# Altium schematic sheet ESP32.SchDoc(ESP32)
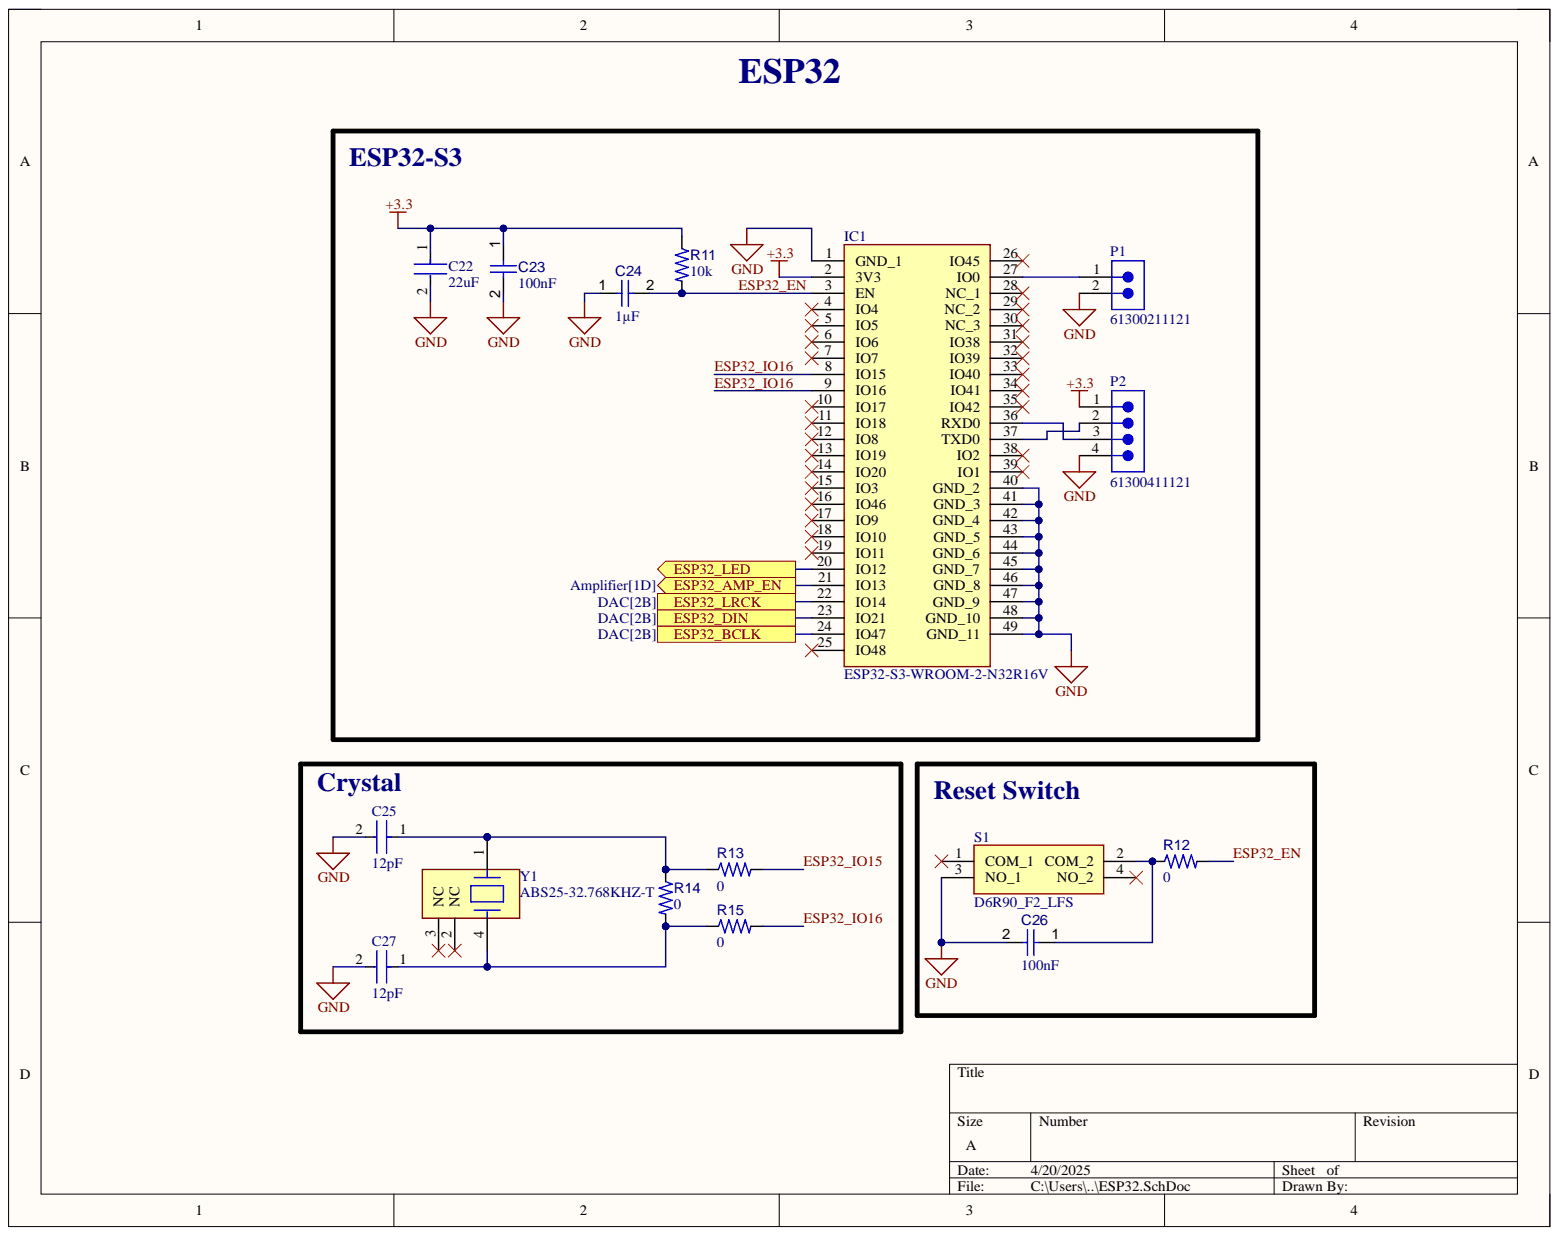
[diagram p0: ESP32.SchDoc]

## Parts
C22  22uF  [FP-GRM188-0_2-IPC_C]
C23  value 100nF  [FP-0603-L_1_6_0_15-W_0_81-IPC_C]  (hidden comment: 06035C104K4T2A)
C24  value 1µF  [CAPC0603(1608)100_L]  (hidden comment: Capacitor 1µF +/-0.1% 25V 0603)
C25  12pF  [FP-C0603C-CF-MFG]
C26  value 100nF  [FP-0603-L_1_6_0_15-W_0_81-IPC_C]  (hidden comment: 06035C104K4T2A)
C27  12pF  [FP-C0603C-CF-MFG]
IC1  ESP32-S3-WROOM-2-N32R16V  [ESP32S3WROOM2N32R16V]
P1  61300211121  [61300211121]
P2  61300411121  [61300411121]
R11  value 10k  [FP-CRCW0603-e3-IPC_C]  (hidden comment: CRCW060310K0JNEA)
R12  value 0  [RESC0603(1608)_L]  (hidden comment: Jumper 0603)
R13  value 0  [RESC0603(1608)_L]  (hidden comment: Jumper 0603)
R14  value 0  [RESC0603(1608)_L]  (hidden comment: Jumper 0603)
R15  value 0  [RESC0603(1608)_L]  (hidden comment: Jumper 0603)
S1  D6R90_F2_LFS  [D6R90F2LFS]
Y1  ABS25-32.768KHZ-T  [FP-ABS25-MFG]

## Ports
ESP32_AMP_EN, ESP32_BCLK, ESP32_DIN, ESP32_LED, ESP32_LRCK

## Nets
+3.3: C22.1 C23.1 IC1.2(3V3) P2.1 R11.1
GND: C22.2 C23.2 C24.1 C25.2 C26.2 C27.2 IC1.1(GND_1) IC1.40(GND_2) IC1.41(GND_3) IC1.42(GND_4) IC1.43(GND_5) IC1.44(GND_6) IC1.45(GND_7) IC1.46(GND_8) IC1.47(GND_9) IC1.48(GND_10) IC1.49(GND_11) P1.2 P2.4 S1.3(NO_1)
ESP32_AMP_EN: IC1.21(IO13) ; port ESP32_AMP_EN
ESP32_BCLK: IC1.24(IO47) ; port ESP32_BCLK
ESP32_DIN: IC1.23(IO21) ; port ESP32_DIN
ESP32_EN: C24.2 IC1.3(EN) R11.2 R12.2
ESP32_IO15: R13.2
ESP32_IO16: IC1.8(IO15) IC1.9(IO16) R15.2
ESP32_LED: IC1.20(IO12) ; port ESP32_LED
ESP32_LRCK: IC1.22(IO14) ; port ESP32_LRCK
NetC25_1: C25.1 R13.1 R14.1 Y1.1
NetC26_1: C26.1 R12.1 S1.2(COM_2)
NetC27_1: C27.1 R14.2 R15.1 Y1.4
NetIC1_27: IC1.27(IO0) P1.1
NetIC1_36: IC1.36(RXD0) P2.3
NetIC1_37: IC1.37(TXD0) P2.2

Not connected: IC1 4(IO4) 5(IO5) 6(IO6) 7(IO7) 10(IO17) 11(IO18) 12(IO8) 13(IO19) 14(IO20) 15(IO3) 16(IO46) 17(IO9) 18(IO10) 19(IO11) 25(IO48) 26(IO45) 28(NC_1) 29(NC_2) 30(NC_3) 31(IO38) 32(IO39) 33(IO40) 34(IO41) 35(IO42) 38(IO2) 39(IO1); S1 1(COM_1) 4(NO_2); Y1 2(NC) 3(NC)

## Library data of the parts
C22 = CMP-2000-06337-3: Chip Multilayer Ceramic Capacitors for General Purpose, 0603, 22uF, X5R, 15%, 20%, 10V; Capacitance: 22uF; Case Code (Imperial): 0603; Case Code (Metric): 1608; Case/Package: 0603; Component Kind: Standard; Depth: 0.8mm; Dielectric: X5R; Height: 0.8mm; Lead Free: Lead Free; Length: 1.6mm; Library Name: Altium Content Vault; Material: Ceramic; Max Operating Temperature: 85°C; Min Operating Temperature: -55°C; Mount: Surface Mount; Package Quantity: 4000; Packaging: Tape and Reel; REACH SVHC: No SVHC; Termination: SMD/SMT; Thickness: 0.039inch; Tolerance: 20%; Voltage Rating: 10V; Voltage Rating (DC): 10V; Weight: 0.000222oz; Width: 0.8mm
C23 C26 = CMP-001-00085-1: Ceramic Capacitor, Multilayer, Ceramic, 50V, 10% +Tol, 10% -Tol, X7R, 15% TC, 0.1uF, Surface Mount, 0603; Capacitor Type: Ceramic; Case/Package: 0603; Component Kind: Standard; Library Name: Spartan Racing Altium Workspace; Max Operating Temperature: 125°C; Min Operating Temperature: -55°C; Mounting Technology: Surface Mount; Pins: 2; RoHS Compliant: Yes
C24 = CMP-001-00055-4: Chip Capacitor, 1µF +/-0.1%, 25V, 0603, Thickness 1 mm; Tolerance: 20%; Case/Package: 0603; Component Kind: Standard; Library Name: Spartan Racing Altium Workspace; Max Operating Temperature: 85°C; Min Operating Temperature: -55°C; Mounting Technology: SMT; Pins: 2; Voltage Rating: 25V
C25 C27 = CMP-2006-03703-3: Ceramic Capacitor 12Pf 50V, C0G, 5%, 0603, Full Reel; Manufacturer: KEMET; Manufacturer Part Number: C0603C120J5GACTU; Automotive Grade: Grade 1; Temperature Coefficient: 30ppm/°C; Tolerance: 0.05; Automotive: Yes; Capacitance: 12 pF; Category: Cap; Component Kind: Standard; ComponentLink2Description: Datasheet; ComponentLink2URL: https://api.kemet.com/component-edge/download/datasheet/C0603C100J5GACAUTO.pdf; Device Class L1: Passive Components; Device Class L2: Capacitors; Device Class L3: Ceramic Capacitors; Digikey Description: Ceramic Capacitor 12Pf 50V, C0G, 5%, 0603, Full Reel; Height: 0.87mm; Lead Free: Yes; Library Name: Altium Content Vault; Material: Ceramic; Mouser Description: Ceramic Capacitor 12Pf 50V, C0G, 5%, 0603, Full Reel; Octopart: https://octopart.com/c0603c120j5gactu-kemet-108780; Package: C0603C-CF; RoHS: TRUE; Temperature: -55°C to +125°C; Temperature Characteristic: C0G; Temperature Range High: +125°C; Temperature Range Low: -55°C; Value: 12 pF; Voltage Rating: 50 V
IC1 = ESP32-S3-WROOM-2-N32R16V: Integrated Circuit; Manufacturer_Name: Espressif Systems; Component Kind: Standard; Datasheet Link: https://www.espressif.com/sites/default/files/documentation/esp32-s3-wroom-2_datasheet_en.pdf; Height: 3.25mm; Manufacturer_Part_Number: ESP32-S3-WROOM-2-N32R16V; Mouser Part Number: 356-SP32S3WM2N32R16V; Mouser Price/Stock: https://www.mouser.co.uk/ProductDetail/Espressif-Systems/ESP32-S3-WROOM-2-N32R16V?qs=%252BHhoWzUJg4Kf%2FwmS40wg%252BQ%3D%3D
P1 = CMP-1712-00002-6: NexarPartId: 20081794; Connector Type: Male Pin; Contact Resistance: 20mΩ; Current Rating: 3A; Gender: Male; Number of Contacts: 2; Operating Supply Voltage: 250V; Pitch: 2.54mm; Color: Black; Component Kind: Standard; Contact Gender: Male; Contact Material: Copper; Contact Mating Length: 5.9944mm; Contact Plating: Gold; Height: 8.94mm; Housing Material: PBT; Lead Free: Lead Free; Lead Pitch: 2.54mm; Library Name: Altium Content Vault; Max Operating Temperature: 125°C; Min Operating Temperature: -40°C; Mount: Through Hole; Number of Pins: 2; Number of Positions: 2; Number of Rows: 1; Orientation: Vertical; Packaging: Bulk; REACH SVHC: No SVHC; RoHS: Compliant; Termination: Through Hole; Voltage Rating: 250V
P2 = CMP-1502-01064-3: NexarPartId: 32855522; Connector Type: Male Pin; Contact Resistance: 20mΩ; Current Rating: 3A; Gender: Male; Number of Contacts: 4; Operating Supply Voltage: 250V; Pitch: 2.54mm; Color: Black; Component Kind: Standard; Contact Material: Copper; Contact Mating Length: 5.9944mm; Contact Plating: Gold; Height: 8.89mm; Lead Free: Lead Free; Lead Pitch: 2.54mm; Library Name: Altium Content Vault; Max Operating Temperature: 105°C; Min Operating Temperature: -40°C; Mount: Through Hole; Number of Pins: 4; Number of Positions: 4; Number of Rows: 1; Orientation: Vertical; Packaging: Bulk; REACH SVHC: Unknown; RoHS: Compliant; Termination: Solder; Voltage Rating: 250V
R11 = CMP-009-00197-4: Fixed Resistor, Metal Glaze/thick Film, 0.1W, 10000ohm, 75V, 5% +/-Tol, 200ppm/Cel, Surface Mount, 0603; Power: 100mW; Case/Package: 0603; Component Kind: Standard; Library Name: Spartan Racing Altium Workspace; Max Operating Temperature: 155°C; Min Operating Temperature: -55°C; Mounting Technology: Surface Mount; Pins: 2; RoHS Compliant: Yes; Tolerance: 5%
R12-R15 = CMP-009-00175-6: Case/Package: 0603; Component Kind: Standard; Description: Jumper 0603; Library Name: Spartan Racing Altium Workspace; Max Operating Temperature: 155°C; Min Operating Temperature: -55°C; Pins: 2
S1 = D6R90_F2_LFS: Switch; Manufacturer_Name: C & K COMPONENTS; Manufacturer_Part_Number: D6R90 F2 LFS; Arrow Part Number: D6R90 F2 LFS; Arrow Price/Stock: https://www.arrow.com/en/products/d6r90f2lfs/ck?utm_currency=USD&region=nac; Component Kind: Standard; Datasheet Link: https://www.digikey.ph/en/products/detail/c-k/D6R90-F2-LFS/1466352; Height: 12.8mm; Mouser Part Number: 611-D6R90F2LFS; Mouser Price/Stock: https://www.mouser.com/Search/Refine.aspx?Keyword=611-D6R90F2LFS
Y1 = CMP-2000-05037-2: CRYSTAL 32.7680KHZ 12.5PF SMD; ESR (Equivalent Series Resistance): 50kR; Frequency Tolerance: 20ppm; Case/Package: SMD/SMT; Component Kind: Standard; Depth: 3.8mm; Frequency: 32.768kHz; Height: 0.098inch; Lead Free: Lead Free; Length: 8mm; Library Name: Altium Content Vault; Load Capacitance: 12.5pF; Max Operating Temperature: 85°C; Min Operating Temperature: -40°C; Mount: Surface Mount; Number of Pins: 4; Operating Frequency: 32.768 kHz; Package Quantity: 1000; Packaging: Tape and Reel; Radiation Hardening: No; REACH SVHC: No SVHC; RoHS Compliant: Yes; Series: ABS25; Series Resistance: 50kR; Termination: SMD/SMT; Tolerance: 20ppm; Width: 3.8mm
